# Altium schematic sheet Control Sigma.SchDoc
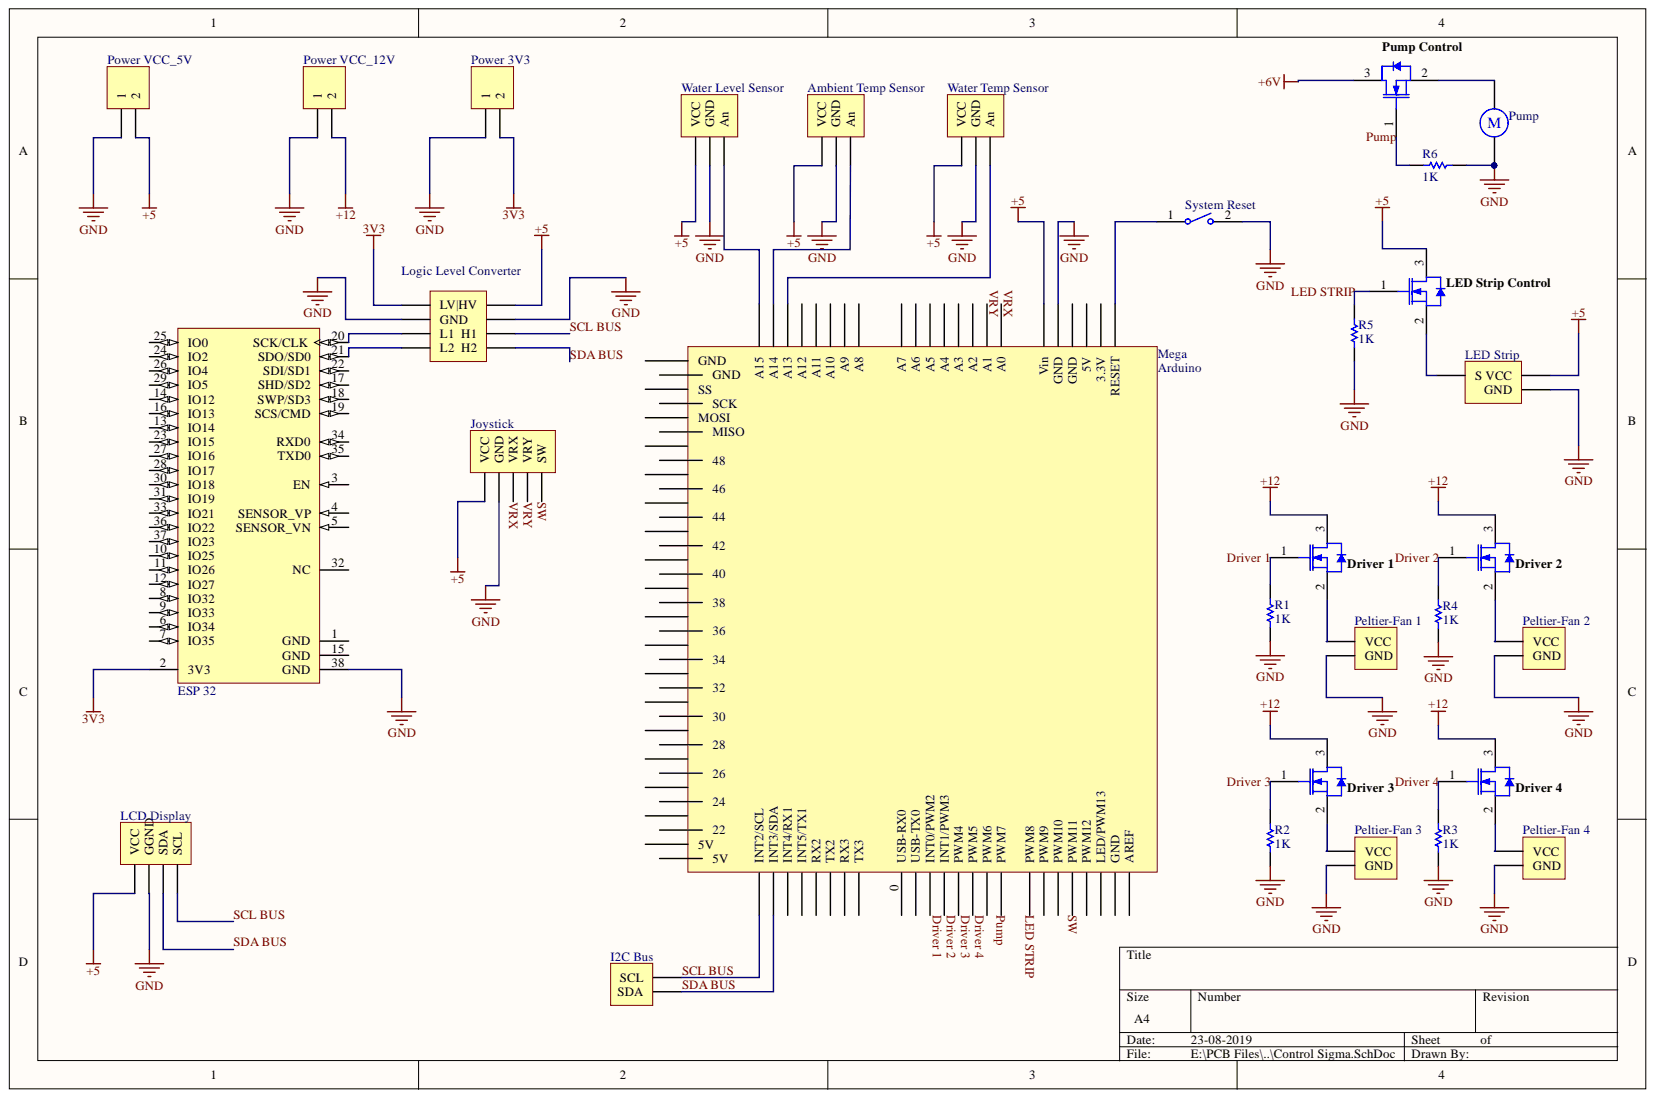
[diagram p0: Control Sigma.SchDoc]

## Parts
Ambient_Temp_Sensor  Header 3  [HDR1X3]  (value not drawn)
Driver_1  2N7002  [INF-PG-SOT23_N]  (value not drawn)
Driver_2  2N7002  [INF-PG-SOT23_N]  (value not drawn)
Driver_3  2N7002  [INF-PG-SOT23_N]  (value not drawn)
Driver_4  2N7002  [INF-PG-SOT23_N]  (value not drawn)
ESP_32  ESP-WROOM-32  [OLMX-ESP-WROOM-32]  (value not drawn)
I2C_Bus  Header 2  [HDR1X2]  (value not drawn)
Joystick  Header 5  [HDR1X5]  (value not drawn)
LCD_Display  Header 4  [HDR1X4]  (value not drawn)
LED_Strip  Header 2X2  [HDR2X2]  (value not drawn)
LED_Strip_Control  2N7002  [INF-PG-SOT23_N]  (value not drawn)
Logic_Level_Converter  Header 4X2  [HDR2X4]  (value not drawn)
Mega  Arduino  [Arduino]
Peltier-Fan_1  Header 2  [HDR1X2]  (value not drawn)
Peltier-Fan_2  Header 2  [HDR1X2]  (value not drawn)
Peltier-Fan_3  Header 2  [HDR1X2]  (value not drawn)
Peltier-Fan_4  Header 2  [HDR1X2]  (value not drawn)
Power_3V3  Header 2  [HDR1X2]  (value not drawn)
Power_VCC_5V  Header 2  [HDR1X2]  (value not drawn)
Power_VCC_12V  Header 2  [HDR1X2]  (value not drawn)
Pump  Motor  [RB5-10.5]  (value not drawn)
Pump_Control  2N7002  [INF-PG-SOT23_N]  (value not drawn)
R1  value 1K  [AXIAL-0.3]  (hidden comment: Res1)
R2  value 1K  [AXIAL-0.3]  (hidden comment: Res1)
R3  value 1K  [AXIAL-0.3]  (hidden comment: Res1)
R4  value 1K  [AXIAL-0.3]  (hidden comment: Res1)
R5  value 1K  [AXIAL-0.3]  (hidden comment: Res1)
R6  value 1K  [AXIAL-0.3]  (hidden comment: Res1)
System_Reset  1825027-5  [TECO-1825027-5_V]  (value not drawn)
Water_Level_Sensor  Header 3  [HDR1X3]  (value not drawn)
Water_Temp_Sensor  Header 3  [HDR1X3]  (value not drawn)

## Nets
3V3: ESP_32.2(3V3) Logic_Level_Converter.1 Power_3V3.2
+5: Ambient_Temp_Sensor.1 Joystick.1(VCC) LCD_Display.1(VCC) LED_Strip.2 LED_Strip_Control.3 Logic_Level_Converter.2(LV|HV) Mega.59(Vin) Power_VCC_5V.2 Water_Level_Sensor.1 Water_Temp_Sensor.1
+6V: Pump_Control.3
+12: Driver_1.3 Driver_2.3 Driver_3.3 Driver_4.3 Power_VCC_12V.2
GND: Ambient_Temp_Sensor.2 ESP_32.38(GND) Joystick.2(GND) LCD_Display.2 LED_Strip.4 Logic_Level_Converter.3(GND) Logic_Level_Converter.4 Mega.58(GND) Peltier-Fan_1.2 Peltier-Fan_2.2 Peltier-Fan_3.2 Peltier-Fan_4.2 Power_3V3.1 Power_VCC_5V.1 Power_VCC_12V.1 Pump.2 R1.1 R2.1 R3.1 R4.1 R5.1 R6.1 System_Reset.2 Water_Level_Sensor.2 Water_Temp_Sensor.2
Driver 1: Driver_1.1 Mega.2(INT0/PWM2) R1.2
Driver 2: Driver_2.1 Mega.3(INT1/PWM3) R4.2
Driver 3: Driver_3.1 Mega.4(PWM4) R2.2
Driver 4: Driver_4.1 Mega.5(PWM5) R3.2
LED STRIP: LED_Strip_Control.1 Mega.8(PWM8) R5.2
Pump: Mega.7(PWM7) Pump_Control.1 R6.2
SCL BUS: I2C_Bus.2 LCD_Display.4(SCL) Logic_Level_Converter.6(H1) Mega.21(INT2/SCL)
SDA BUS: I2C_Bus.1 LCD_Display.3(SDA) Logic_Level_Converter.8(H2) Mega.20(INT3/SDA)
SW: Joystick.5(SW) Mega.11(PWM11)
VRX: Joystick.3(VRX) Mega.60(A0)
VRY: Joystick.4(VRY) Mega.61(A1)
NetAmbient Temp Sensor_3: Ambient_Temp_Sensor.3 Mega.74(A14)
NetDriver 1_2: Driver_1.2 Peltier-Fan_1.1
NetDriver 2_2: Driver_2.2 Peltier-Fan_2.1
NetDriver 3_2: Driver_3.2 Peltier-Fan_3.1
NetDriver 4_2: Driver_4.2 Peltier-Fan_4.1
NetESP 32_20: ESP_32.20(SCK/CLK) Logic_Level_Converter.5(L1)
NetESP 32_21: ESP_32.21(SDO/SD0) Logic_Level_Converter.7(L2)
NetLED Strip_1: LED_Strip.1 LED_Strip_Control.2
NetMega_54: Mega.54(RESET) System_Reset.1
NetMega_73: Mega.73(A13) Water_Temp_Sensor.3
NetMega_75: Mega.75(A15) Water_Level_Sensor.3
NetPump_1: Pump.1 Pump_Control.2

Not connected: ESP_32 1(GND) 3(EN) 4(SENSOR_VP) 5(SENSOR_VN) 6(IO34) 7(IO35) 8(IO32) 9(IO33) 10(IO25) 11(IO26) 12(IO27) 13(IO14) 14(IO12) 15(GND) 16(IO13) 17(SHD/SD2) 18(SWP/SD3) 19(SCS/CMD) 22(SDI/SD1) 23(IO15) 24(IO2) 25(IO0) 26(IO4) 27(IO16) 28(IO17) 29(IO5) 30(IO18) 31(IO19) 32(NC) 33(IO21) 34(RXD0) 35(TXD0) 36(IO22) 37(IO23); Mega 0(USB-RX0) 1(USB-TX0) 6(PWM6) 9(PWM9) 10(PWM10) 12(PWM12) 13(LED/PWM13) 14(TX3) 15(RX3) 16(TX2) 17(RX2) 18(INT5/TX1) 19(INT4/RX1) 22 23 24 25 26 27 28 29 30 31 32 33 34 35 36 37 38 39 40 41 42 43 44 45 46 47 48 49 50(MISO) 51(MOSI) 52(SCK) 53(SS) 55(3.3V) 56(5V) 57(GND) 62(A2) 63(A3) 64(A4) 65(A5) 66(A6) 67(A7) 68(A8) 69(A9) 70(A10) 71(A11) 72(A12) 76(GND) 77(AREF) 78(GND) 79(GND) 80(5V) 81(5V)

## Library data of the parts
Ambient_Temp_Sensor Water_Level_Sensor Water_Temp_Sensor = Header 3: Header, 3-Pin; Component Kind: Standard; LatestRevisionDate: 17-Jul-2002; LatestRevisionNote: Re-released for DXP Platform.; Library Name: Miscellaneous Connectors.IntLib; Signal Integrity: Connector; Publisher: Altium Limited
Driver_1-Driver_4 LED_Strip_Control Pump_Control = 2N7002: N-Channel OptiMOS Small-Signal-Transistor, 60 V VDS, 0.3 A ID, -55 to 150 degC, PG-SOT23, Reel, Green; Manufacturer: Infineon; Green: TRUE; ID (max): 0.3 A; IDpuls (max): 1.2 A; Ptot (max): 0.5 W; QG: 0.4 nC; RDS (on) (max): 3,000 mOhm; RDS (on) (max) (@4.5V): 4,000 mOhm; RthJA (max): 250 K/W; VDS (max): 60 V; VGS(th) (max): 2.5 V; VGS(th) (min): 1.5 V; Component Kind: Standard; ComponentLink1Description: Manufacturer URL; ComponentLink1URL: http://www.infineon.com/; ComponentLink2Description: Datasheet; ComponentLink2URL: http://www.infineon.com/dgdl/2N7002_Rev2.4.pdf?folderId=db3a3043156fd573011622e10b5c1f67&fileId=db3a304319bc939a0119c2a9461e79e6; ComponentLink3Description: Package Specification; ComponentLink3URL: http://www.infineon.com/dgdl/SOT23-Package_Overview.pdf?folderId=db3a30431936bc4b0119532290053c66&fileId=db3a30431936bc4b0119533468123c85; DatasheetVersion: Rev. 2.4; Halogen-free: TRUE; Library Name: Infineon_Power_MOSFET.IntLib; Mounting Technology: Surface Mount; PackageDescription: 3-Pin Small Outline Transistor Package, 2.9 x 1.3 mm Body, 0.95 mm Pitch; PackageReference: PG-SOT23; PackageVersion: Apr-08; Packing: Reel; PartNumber: 2N7002; Supplier 1: Arrow; Supplier Part Number 1: 6oGnorwKIcmhtwqcFeJ1owu1VFxg26S-d6kZGECfY84
ESP_32 = CMP-2000-07454-1: Espressif System, 2.7 to 3.6 V, -40 to 85 degC, 38-Pin SMD; Manufacturer: Olimex; Component Kind: Standard; Datasheet URL: http://www.espressif.com/sites/default/files/documentation/esp-wroom-32_datasheet_en.pdf; Datasheet Version: Rev. 2.1, 09/2017; Library Name: ESP WROOM 32.IntLib; Manufacturer URL: https://www.olimex.com; Mounting Technology: SurfaceMount; Package Description: 38-Pin Surface Mount Device, Body 18 x 25.5 mm, Pitch 1.27 mm; Package Reference: ESP-WROOM-32; RoHSCompliant: false
I2C_Bus Peltier-Fan_1-Peltier-Fan_4 Power_3V3 Power_VCC_5V Power_VCC_12V = Header 2: Header, 2-Pin; Component Kind: Standard; LatestRevisionDate: 17-Jul-2002; LatestRevisionNote: Re-released for DXP Platform.; Library Name: Miscellaneous Connectors.IntLib; Signal Integrity: Connector; Publisher: Altium Limited
Joystick = Header 5: Header, 5-Pin; Component Kind: Standard; LatestRevisionDate: 17-Jul-2002; LatestRevisionNote: Re-released for DXP Platform.; Library Name: Miscellaneous Connectors.IntLib; Signal Integrity: Connector; Publisher: Altium Limited
LCD_Display = Header 4: Header, 4-Pin; Component Kind: Standard; LatestRevisionDate: 17-Jul-2002; LatestRevisionNote: Re-released for DXP Platform.; Library Name: Miscellaneous Connectors.IntLib; Signal Integrity: Connector; Publisher: Altium Limited
LED_Strip = Header 2X2: Header, 2-Pin, Dual row; Component Kind: Standard; LatestRevisionDate: 17-Jul-2002; LatestRevisionNote: Re-released for DXP Platform.; Library Name: Miscellaneous Connectors.IntLib; Signal Integrity: Connector; Publisher: Altium Limited
Logic_Level_Converter = Header 4X2: Header, 4-Pin, Dual row; Component Kind: Standard; LatestRevisionDate: 17-Jul-2002; LatestRevisionNote: Re-released for DXP Platform.; Library Name: Miscellaneous Connectors.IntLib; Signal Integrity: Connector; Publisher: Altium Limited
Pump = Motor: Motor, General Kind; Component Kind: Standard; LatestRevisionDate: 17-Jul-2002; LatestRevisionNote: Re-released for DXP Platform.; Library Name: Miscellaneous Devices.IntLib; PackageDescription: Capacitor (Radial); 2 Leads; PackageReference: RB5-10.5; Published: 24-Mar-1999; Publisher: Altium Limited
R1-R6 = Res1: Resistor; Component Kind: Standard; LatestRevisionDate: 17-Jul-2002; LatestRevisionNote: Re-released for DXP Platform.; Library Name: Miscellaneous Devices.IntLib; PackageDescription: Axial Device, Thru-Hole; 2 Leads; 0.3 in Pin Spacing; PackageReference: AXIAL-0.3; Published: 8-Jun-2000; Signal Integrity: Res; Simulation: RESISTOR; Publisher: Altium Limited
System_Reset = CMP-2000-05514-1: Micro-Miniature Pushbutton Switch, 0.05 A, 24 VDC, -35 to 85 degC, 2-Pin THD, RoHS, Bulk; Manufacturer: TEConnectivity/AMPBrand; Actuator Color: Black; Actuator Length: 1.24mm; Actuator Material: PolybutyleneTerephthalate; Actuator Style: RoundButton; Body Orientation: Straight; Bushing Type: Unthreaded; Contact Rating @ Voltage: 0.05A@24VDC; Dielectric Strength: 500VAC; Electrical Life: 100000Cycle; Function: MomentaryContact; Illumination: N/R; Maximum Contact Resistance: 100mOhm; Maximum DC Voltage Rating: 24V; Pole Throw Configuration: SPST; Switch Configuration: OFF(ON); Base Material: N/A; Component Kind: Standard; Contact Material: CopperAlloy; Contact Plating: Silver; Datasheet URL: http://www.te.com/commerce/DocumentDelivery/DDEController?Action=srchrtrv&DocNm=1825027&DocType=Customer+Drawing&DocLang=English&DocFormat=pdf&PartCntxt=1825027-8; Datasheet Version: Rev. G4, 06/2011; Dimension: 7.11x7.87x7.01mm; Family: 1825027; Housing Material: N/A; Library Name: Altium Content Vault; Manufacturer URL: http://www.te.com/; Maximum AC Voltage Rating: N/A; Mechanical Life: N/A; Minimum Insulation Resistance: 100MOhm; Mounting: ThroughHole; Mounting Technology: ThroughHole; Operating Temperature: -35to85C; Package Description: 2-Pin Through Hole Device, Body 7.87 x 7.11 mm; Package Reference: 1825027-5; Packaging: N/A; Part No: 1825027-5; Product Weight: N/A; RoHS: Yes; Seal Description: N/A; Seal Location: N/A; Terminal Type: PCPins; Thread Size: N/R
Mega = Arduino: Component Kind: Standard; Library Name: Arduino Mega.SchLib; PCB3D: Arduino
